# Altium schematic sheet receiver.SchDoc(receiver)
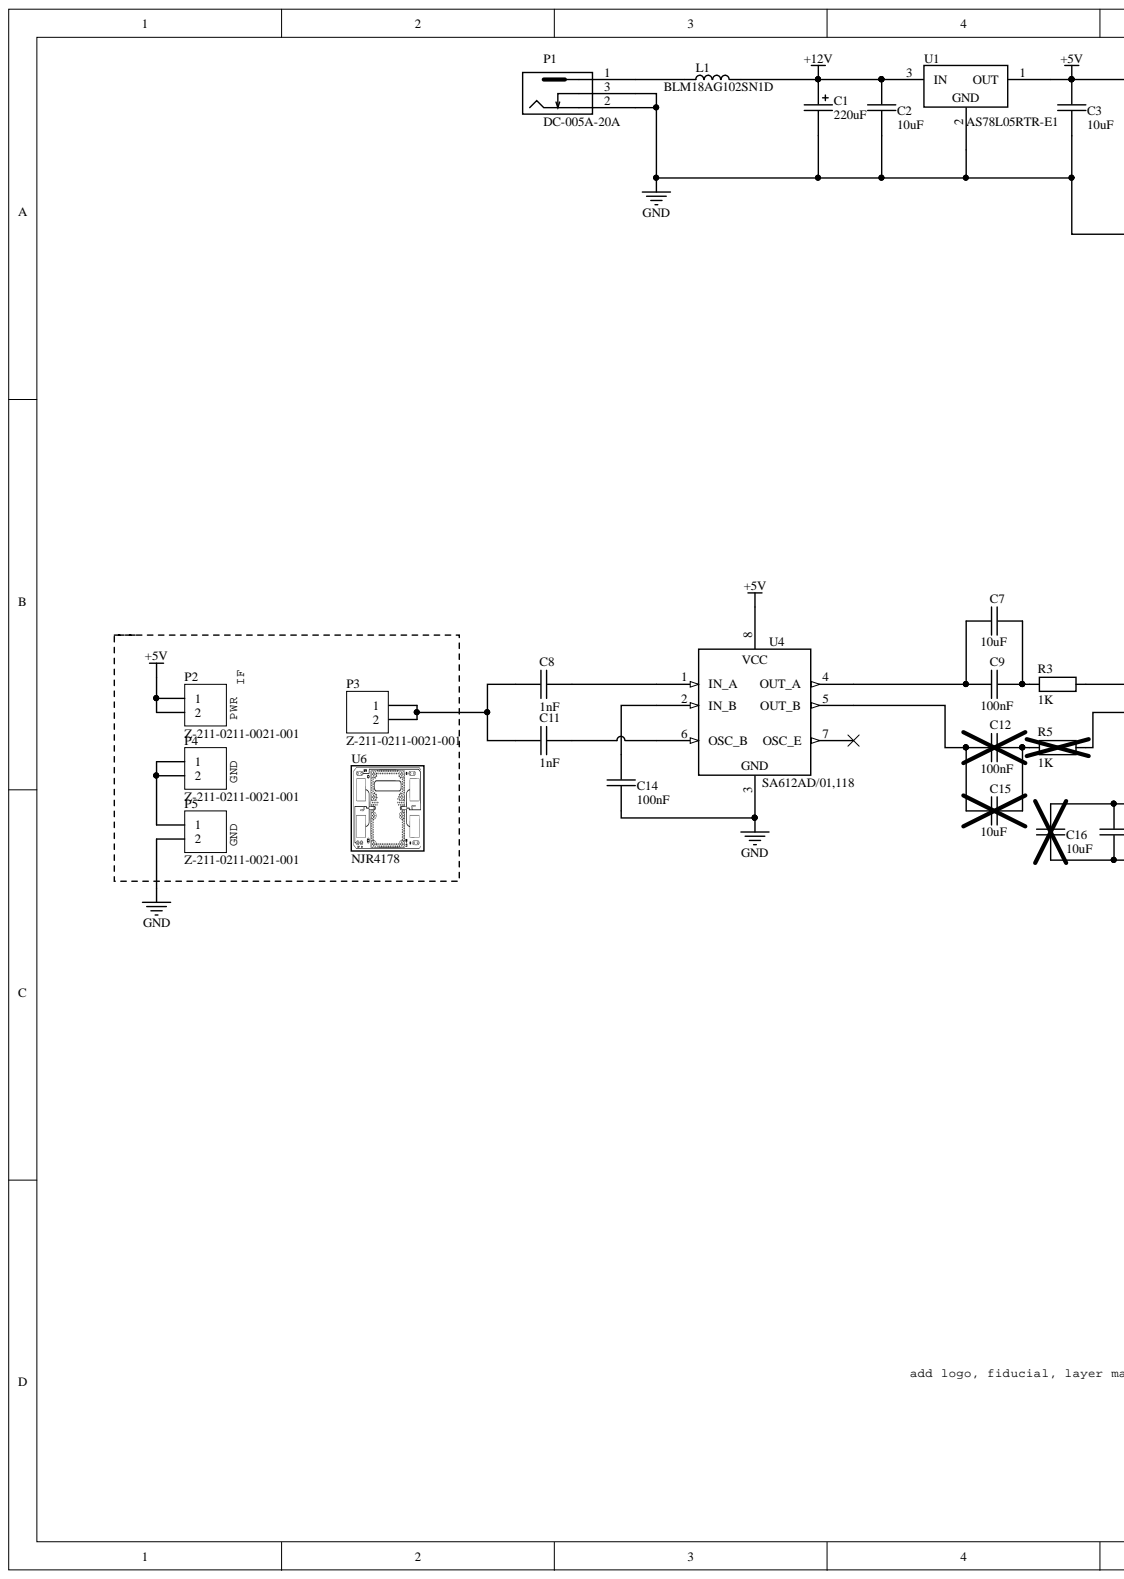
[diagram p0: receiver.SchDoc, left]
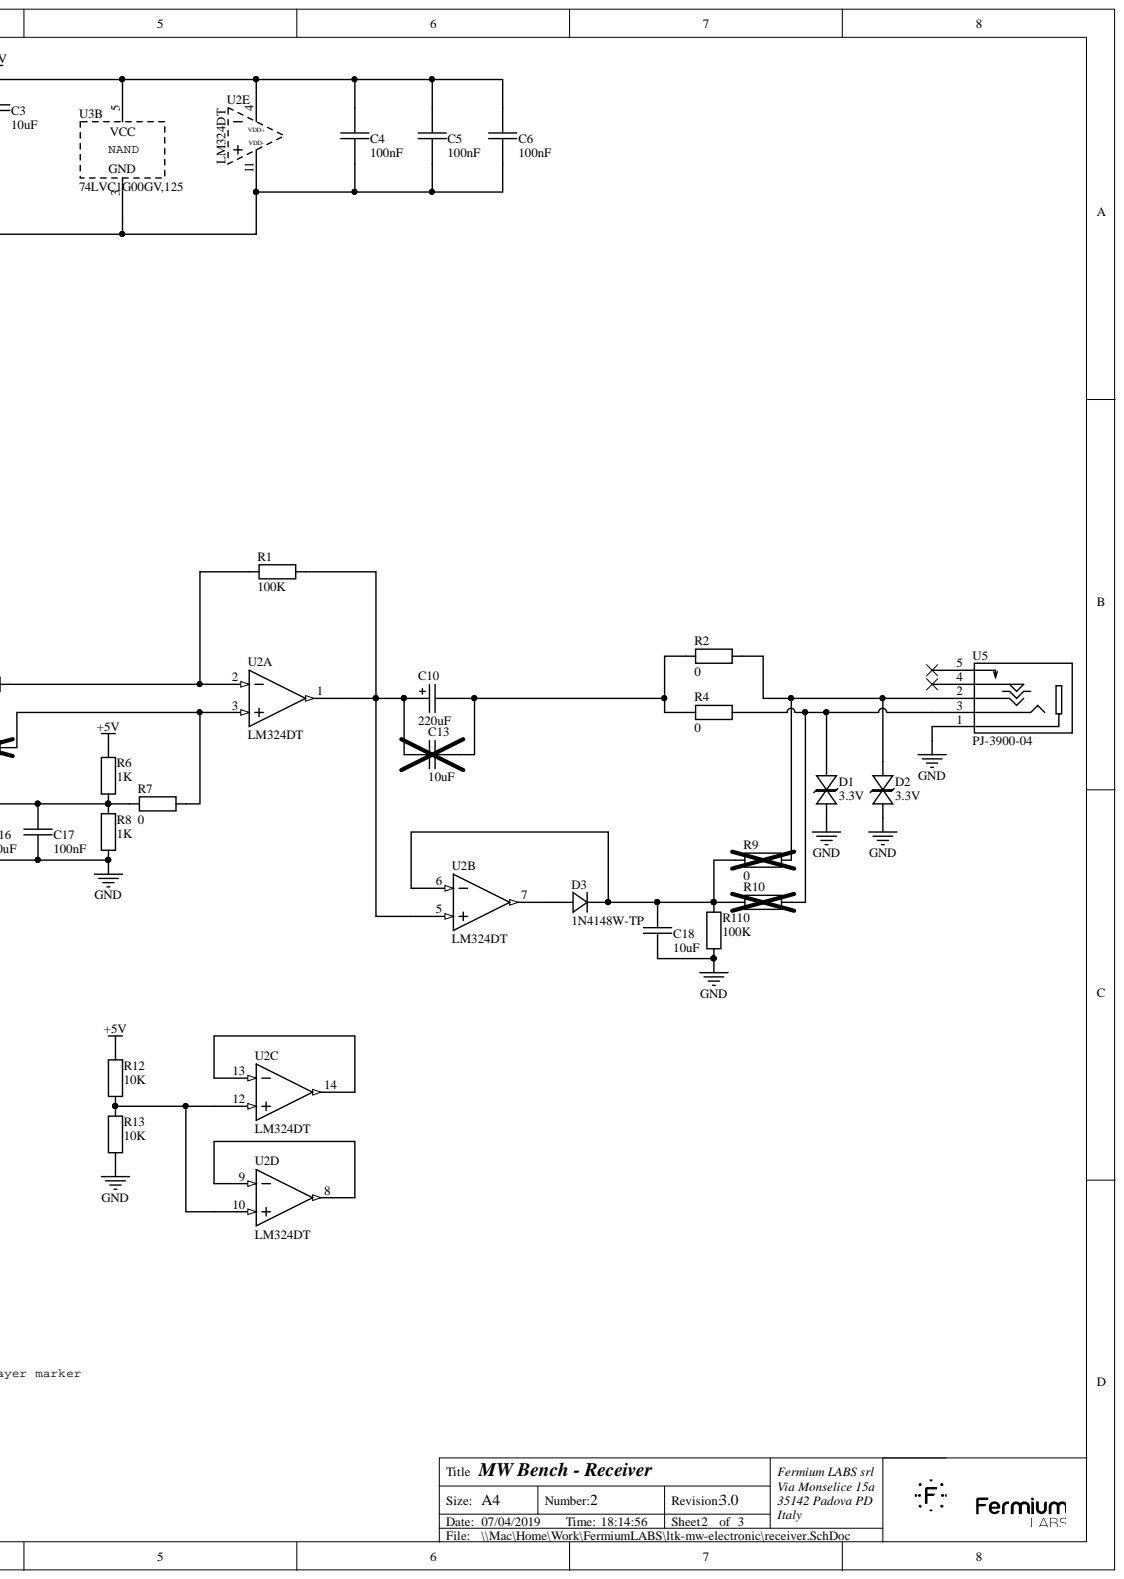
[diagram p1: receiver.SchDoc, right]

## Parts
C1  220uF  [3924B366-8672-45E9-B0BE-62DACC24E783]
C2  10uF  [CAP 0805_2012]
C3  10uF  [CAP 0805_2012]
C4  100nF  [CAP 0603_1608]
C5  100nF  [CAP 0603_1608]
C6  100nF  [CAP 0603_1608]
C7  10uF  [CAP 0805_2012]
C8  1nF  [CAP 0603_1608]
C9  100nF  [CAP 0603_1608]
C10  220uF  [3924B366-8672-45E9-B0BE-62DACC24E783]
C11  1nF  [CAP 0603_1608]
C12  100nF  [CAP 0603_1608]
C13  10uF  [CAP 0805_2012]
C14  100nF  [CAP 0603_1608]
C15  10uF  [CAP 0805_2012]
C16  10uF  [CAP 0805_2012]
C17  100nF  [CAP 0603_1608]
C18  10uF  [CAP 0805_2012]
D1  3.3V
D2  3.3V
D3  1N4148W-TP
L1  BLM18AG102SN1D  [FER 0603_1608]
P1  DC-005A-20A  [F0972D8A-C5C0-4A84-836D-A9666EE65C2D]
P2  Z-211-0211-0021-001
P3  Z-211-0211-0021-001
P4  Z-211-0211-0021-001
P5  Z-211-0211-0021-001
R1  100K
R2  0
R3  1K  [RES 0603_1608]
R4  0
R5  1K  [RES 0603_1608]
R6  1K  [RES 0603_1608]
R7  0
R8  1K  [RES 0603_1608]
R9  0
R10  0
R11  100K
R12  10K
R13  10K
U1  AS78L05RTR-E1  [E733EFB9-FE91-4AE9-9197-29251971C3DC]
U2  LM324DT  (parts A,B,C,D,E)
U3  74LVC1G00GV,125  (part B)
U4  SA612AD/01,118  [TI SOIC-8 D]
U5  PJ-3900-04
U6  NJR4178  [25B19271-CD6F-4BC8-A99B-F617D566DC9C]

## Nets
+5V: C3.2 C4.2 C5.2 C6.2 P2.1 P2.2 R6.2 R12.2 U1.1 U2.4 U3.5 U4.8
+12V: C1.1 C2.2 L1.2 U1.3
GND: C1.2 C2.1 C3.1 C4.1 C5.1 C6.1 C14.2 C16.1 C17.1 C18.2 D1.1 D2.1 P1.2 P1.3 P5.2 R8.1 R11.1 R13.1 U1.2 U2.11 U3.3 U4.3 U5.1
NetC7_1: C7.1 C9.1 U4.4(OUT_A)
NetC7_2: C7.2 C9.2 R3.1
NetC8_1: C8.1 C11.1 P3.1 P3.2
NetC8_2: C8.2 U4.1(IN_A)
NetC10_1: C10.1 C13.2 R1.1 U2.1 U2.5
NetC10_2: C10.2 C13.1 R2.2 R4.2
NetC11_2: C11.2 U4.6(OSC_B)
NetC12_1: C12.1 C15.1 U4.5(OUT_B)
NetC12_2: C12.2 C15.2 R5.1
NetC14_1: C14.1 U4.2(IN_B)
NetC16_2: C16.2 C17.2 R6.1 R7.2 R8.2
NetC18_1: C18.1 D3.2 R9.2 R10.2 R11.2 U2.6
NetD1_2: D1.2 R4.1 R10.1 U5.3
NetD2_2: D2.2 R2.1 R9.1 U5.2
NetD3_1: D3.1 U2.7
NetL1_1: L1.1 P1.1
NetP4_1: P4.1 P4.2 P5.1
NetR1_2: R1.2 R3.2 U2.2
NetR5_2: R5.2 R7.1 U2.3
NetR12_1: R12.1 R13.2 U2.10 U2.12
NetU2_8: U2.8 U2.9
NetU2_13: U2.13 U2.14

Not connected: U4 7(OSC_E); U5 4 5

## Library data of the parts
C1 C10 = 1a28df19-6d3e-4a71-836c-f22920f8e8ab: CAP ELECTROLYTIC THT 220uF 25V 20%; Manufacturer Part Number 1: 25PK220MEFC-6.3*11; Manufacturer 1: Rubycon; id: 14782; Database Table Name: public.fermiumlabs_all_pivoted; Part Number: 1a28df19-6d3e-4a71-836c-f22920f8e8ab; ComponentLink1URL: http://localhost:8080/static/files/Rubycon-220uF-25V_C109337_12019-03-10_214953.757848.pdf?Rubycon-220uF-25V_C109337 (1).pdf; ComponentLink1Description: Datasheet; Component Kind: Standard; Library Name: frmdblib.DbLib
C2 C3 C7 C13 C15 C16 C18 = 1ffbe5a9-0884-4740-bdad-20a6a98400e6: CAP SMD CHIP 10uF 25V 0805 X5R; Manufacturer Part Number 1: CL21A106KAYNNNE; Manufacturer 1: Samsung Electro-Mechanics; id: 14779; Database Table Name: public.fermiumlabs_all_pivoted; Part Number: 1ffbe5a9-0884-4740-bdad-20a6a98400e6; ComponentLink1URL: http://localhost:8080/static/files/Samsung-Electro-Mechanics-CL21A106KAYNNNE_C158502019-03-10_213827.610739.pdf?Samsung-Electro-Mechanics-CL21A106KAYNNNE_C15850.pdf; ComponentLink1Description: Datasheet; Component Kind: Standard; Library Name: frmdblib.DbLib
C4-C6 C9 C12 C14 C17 = 02841f84-ac15-41b5-9e17-915f8f067828: CAP SMD CHIP 100nF 25V 0603 X7R; Manufacturer Part Number 1: CL10B104KA8NNNC; Manufacturer 1: Samsung Electro-Mechanics; id: 14784; Database Table Name: public.fermiumlabs_all_pivoted; Part Number: 02841f84-ac15-41b5-9e17-915f8f067828; ComponentLink1URL: http://localhost:8080/static/files/Samsung-Electro-Mechanics-CL10B104KA8NNNC_C15902019-03-10_215548.826887.pdf?Samsung-Electro-Mechanics-CL10B104KA8NNNC_C1590.pdf; ComponentLink1Description: Datasheet; Component Kind: Standard; Library Name: frmdblib.DbLib
C8 C11 = 0eca2548-3630-4fea-a3d5-94248c1cae74: CAP SMD CHIP 1nF 50V 0603 X7R; Manufacturer Part Number 1: CL10B102KB8NNNC; Manufacturer 1: Samsung Electro-Mechanics; id: 14785; Database Table Name: public.fermiumlabs_all_pivoted; Part Number: 0eca2548-3630-4fea-a3d5-94248c1cae74; ComponentLink1URL: http://localhost:8080/static/files/Samsung-Electro-Mechanics-CL10B102KB8NNNC_C1588_12019-03-10_215732.131818.pdf?Samsung-Electro-Mechanics-CL10B102KB8NNNC_C1588 (1).pdf; ComponentLink1Description: Datasheet; Component Kind: Standard; Library Name: frmdblib.DbLib
D1 D2 = d6266fd0-7428-4fb9-a062-338cdce926fd: DIODE TVS 3.3V BIDIRECTIONAL SOD-523; Manufacturer Part Number 1: PESD3V3S1BA-N; Manufacturer 1: Born Semiconductor; id: 14798; Database Table Name: public.fermiumlabs_all_pivoted; Value: 3.3V; Part Number: d6266fd0-7428-4fb9-a062-338cdce926fd; ComponentLink1URL: http://localhost:8080/static/files/Bourne-Semicon-Shenzhen-PESD3V3S1BA-N_C3160372019-04-07_002431.794112.pdf?Bourne-Semicon-Shenzhen-PESD3V3S1BA-N_C316037.pdf; ComponentLink1Description: Datasheet; Component Kind: Standard; Library Name: frmdblib.DbLib
D3 = 5e920700-75df-48e3-84dd-8f9f777caed8: DIODE SMD 100V 150mA 1.5Vf SOD-123 4148; Manufacturer 1: MCC; id: 14795; Database Table Name: public.fermiumlabs_all_pivoted; Part Number: 5e920700-75df-48e3-84dd-8f9f777caed8; Manufacturer Part Number 1: 1N4148W-TP; ComponentLink1URL: http://localhost:8080/static/files/Micro-Commercial-Components-MCC-1N4148W-TP_C779782019-04-06_215019.428643.pdf?Micro-Commercial-Components-MCC-1N4148W-TP_C77978.pdf; ComponentLink1Description: Datasheet; Component Kind: Standard; Library Name: frmdblib.DbLib
L1 = c9e30ae8-9d5b-4135-a079-b1147da846d8: FER SMD CHIP 0603 400mA 1k@100MHz 500mOhm; Manufacturer 1: Murata; id: 14786; Database Table Name: public.fermiumlabs_all_pivoted; Part Number: c9e30ae8-9d5b-4135-a079-b1147da846d8; Manufacturer Part Number 1: BLM18AG102SN1D; ComponentLink1URL: http://localhost:8080/static/files/Murata-Electronics-BLM18AG102SN1D_C858242019-03-10_220021.186607.pdf?Murata-Electronics-BLM18AG102SN1D_C85824.pdf; ComponentLink1Description: Datasheet; Component Kind: Standard; Library Name: frmdblib.DbLib
P1 = b53db51a-1725-412b-9039-fa7a45c7c438: CONN DC JACK 0.5A 30VDC 5Kcycles; Manufacturer 1: HRO Electronics; id: 14780; Database Table Name: public.fermiumlabs_all_pivoted; Part Number: b53db51a-1725-412b-9039-fa7a45c7c438; Manufacturer Part Number 1: DC-005A-20A; ComponentLink1URL: http://localhost:8080/static/files/Korean-Hroparts-Elec-DC-005A-20A_C191049_22019-03-10_214231.486078.pdf?Korean-Hroparts-Elec-DC-005A-20A_C191049 (2).pdf; ComponentLink1Description: Datasheet; Component Kind: Standard; Library Name: frmdblib.DbLib
P2-P5 = fb9043ef-1dd5-4a9c-8c1a-da0c667690ac: CONN PIN HEADER 2P MALE 2.54mm; Manufacturer 1: Nextronics Engineering; id: 14796; Database Table Name: public.fermiumlabs_all_pivoted; Part Number: fb9043ef-1dd5-4a9c-8c1a-da0c667690ac; Manufacturer Part Number 3: ---; Manufacturer Part Number 2: A2541WV-2P; Manufacturer Part Number 1: Z-211-0211-0021-001; Manufacturer 3: Shenzhen Cankemeng; Manufacturer 2: Changjiang Connectors; ComponentLink3URL: http://localhost:8080/static/files/Changjiang-Connectors-A2541WV-2P_C2254772019-04-06_220058.382973.pdf?Changjiang-Connectors-A2541WV-2P_C225477.pdf; ComponentLink3Description: Datasheet; ComponentLink2URL: http://localhost:8080/static/files/Nextronics-Engineering-Z-211-0211-0021-001_C1521542019-04-06_220050.159477.pdf?Nextronics-Engineering-Z-211-0211-0021-001_C152154.pdf; ComponentLink2Description: Datasheet; ComponentLink1URL: http://localhost:8080/static/files/Shenzhen-Cankemeng-Headers-Pins-1-2P-2-54mm-Straight-line_C1243752019-04-06_220043.339618.pdf?Shenzhen-Cankemeng-Headers-Pins-1-2P-2-54mm-Straight-line_C124375.pdf; ComponentLink1Description: Datasheet; Component Kind: Standard; Library Name: frmdblib.DbLib
R1 R11 = 76af10b3-9d85-4b5c-a2d6-26cc74f432f7: RES SMD 100K OHM 1% 1/10W 0603; Manufacturer Part Number 1: RC0603FR-07100KL; Manufacturer 1: Yageo; id: 12746; Database Table Name: public.fermiumlabs_all_pivoted; Value: 100K; Part Number: 76af10b3-9d85-4b5c-a2d6-26cc74f432f7; Component Kind: Standard; Library Name: frmdblib.DbLib
R2 R4 R7 R9 R10 = 6ae227f9-847f-4766-8522-e11a83531204: RES SMD 0 OHM 5% 1/10W 0603; Manufacturer Part Number 1: RC0603JR-070RL; Manufacturer 1: Yageo; id: 11973; Database Table Name: public.fermiumlabs_all_pivoted; Value: 0; Part Number: 6ae227f9-847f-4766-8522-e11a83531204; Component Kind: Standard; Library Name: frmdblib.DbLib
R3 R5 R6 R8 = a678220f-9e9d-4863-a833-9993504f723c: RES SMD 1K OHM 1% 1/10W 0603; Manufacturer Part Number 1: RC0603FR-071KL; Manufacturer 1: Yageo; id: 14794; Database Table Name: public.fermiumlabs_all_pivoted; Value: 1K; Part Number: a678220f-9e9d-4863-a833-9993504f723c; Component Kind: Standard; Library Name: frmdblib.DbLib
R12 R13 = 93242766-ba1d-462d-b58f-ce1dfc4129c8: RES SMD 10K OHM 1% 1/10W 0603; Manufacturer Part Number 1: RC0603FR-0710KL; Manufacturer 1: Yageo; id: 12101; Database Table Name: public.fermiumlabs_all_pivoted; Value: 10K; Part Number: 93242766-ba1d-462d-b58f-ce1dfc4129c8; Component Kind: Standard; Library Name: frmdblib.DbLib
U1 = fd64863e-487f-4688-b264-696c0ba5456b: IC LINEAR VOLTAGE REGULATOR 5V 100mA; Manufacturer 1: Diodes Inc.; id: 14783; Database Table Name: public.fermiumlabs_all_pivoted; Part Number: fd64863e-487f-4688-b264-696c0ba5456b; Manufacturer Part Number 2: KIA78L05T; Manufacturer Part Number 1: AS78L05RTR-E1; Manufacturer 2: KIA Semicon Tech; ComponentLink2URL: http://localhost:8080/static/files/KIA-Semicon-Tech-KIA78L05T_C90763_12019-03-10_215415.523371.pdf?KIA-Semicon-Tech-KIA78L05T_C90763 (1).pdf; ComponentLink2Description: Datasheet; ComponentLink1URL: http://localhost:8080/static/files/Diodes-Incorporated-AS78L05RTR-E1_C90471_12019-03-10_215252.941962.pdf?Diodes-Incorporated-AS78L05RTR-E1_C90471 (1).pdf; ComponentLink1Description: Datasheet; Component Kind: Standard; Library Name: frmdblib.DbLib
U2 = 21a85167-c328-42f7-a52e-365842c6dad3: IC OPAMP LM324DT SO-14; Manufacturer 1: ST Microelectronics; id: 14792; Database Table Name: public.fermiumlabs_all_pivoted; Part Number: 21a85167-c328-42f7-a52e-365842c6dad3; Manufacturer Part Number 1: LM324DT; ComponentLink1URL: http://localhost:8080/static/files/STMicroelectronics-LM324DT_C710352019-04-06_191500.254110.pdf?STMicroelectronics-LM324DT_C71035.pdf; ComponentLink1Description: Datasheet; Component Kind: Standard; Library Name: frmdblib.DbLib
U3 = 33900555-dbb4-4b72-871f-952983a59b08: IC NAND GATE 2 INPUTS 74X00 SC-74A; Manufacturer 1: Nexperia; id: 14797; Database Table Name: public.fermiumlabs_all_pivoted; Part Number: 33900555-dbb4-4b72-871f-952983a59b08; Manufacturer Part Number 1: 74LVC1G00GV,125; ComponentLink1URL: http://localhost:8080/static/files/Nexperia-74LVC1G00GV-125_C1313082019-04-06_222642.812294.pdf?Nexperia-74LVC1G00GV-125_C131308.pdf; ComponentLink1Description: Datasheet; Component Kind: Standard; Library Name: frmdblib.DbLib
U4 = a9622f6c-68f2-4f08-abc9-76f38977fdd3: IC RF MIXER SA612A SOIC-8; Manufacturer 1: NXP Semiconductors; id: 14790; Database Table Name: public.fermiumlabs_all_pivoted; Part Number: a9622f6c-68f2-4f08-abc9-76f38977fdd3; Manufacturer Part Number 1: SA612AD/01,118; ComponentLink3URL: http://localhost:8080/static/files/NXP_Semiconductors-rohs_statement2019-03-11_010314.569812.pdf?NXP_Semiconductors-rohs_statement.pdf; ComponentLink3Description: RoHS Statement; ComponentLink2URL: http://localhost:8080/static/files/NXP_Semiconductors-SA612AD_01118-datasheet_32019-03-11_010254.258631.pdf?NXP_Semiconductors-SA612AD_01,118-datasheet (3).pdf; ComponentLink2Description: Datasheet; ComponentLink1URL: http://localhost:8080/static/files/SA612AD-01118-Philips2019-03-11_010245.512050.pdf?SA612AD-01,118-Philips.pdf; ComponentLink1Description: Datasheet; Component Kind: Standard; Library Name: frmdblib.DbLib
U5 = 80507a24-5d7c-4cf5-953e-f54832d54564: CONN 3.5mm AUDIO STEREO AUX SWITCH; Manufacturer 1: HRO Electronics; id: 14793; Database Table Name: public.fermiumlabs_all_pivoted; Part Number: 80507a24-5d7c-4cf5-953e-f54832d54564; Manufacturer Part Number 1: PJ-3900-04; Component Kind: Standard; Library Name: frmdblib.DbLib
U6 = f0e19a38-18de-4253-8da2-aebb0c4083bd: MODULE RADAR DOPPLER 10.525GHz NJR4178; Manufacturer 1: New Japan Radio; id: 14789; Database Table Name: public.fermiumlabs_all_pivoted; Part Number: f0e19a38-18de-4253-8da2-aebb0c4083bd; Manufacturer Part Number 1: NJR4178; ComponentLink4URL: https://micro.njr.com/eng/products/sensor/doppler/r4178.html; ComponentLink4Description: Product Page; ComponentLink3URL: http://localhost:8080/static/files/201812111056099982019-03-10_230743.103809.pdf?20181211105609998.pdf; ComponentLink3Description: Quote; ComponentLink2URL: http://localhost:8080/static/files/FERMIUM_LABS_Moduli_NJR41782019-03-10_230730.901712.pdf?FERMIUM LABS  Moduli NJR4178.pdf; ComponentLink2Description: Corrispondence; ComponentLink1URL: http://localhost:8080/static/files/Xdoppler_NJR41767881_rev032019-03-10_230533.619662.pdf?Xdoppler_NJR4176^78^81_rev03.pdf; ComponentLink1Description: Datasheet; Component Kind: Standard; Library Name: frmdblib.DbLib
